# Altium schematic sheet USBandPOWER.SchDoc
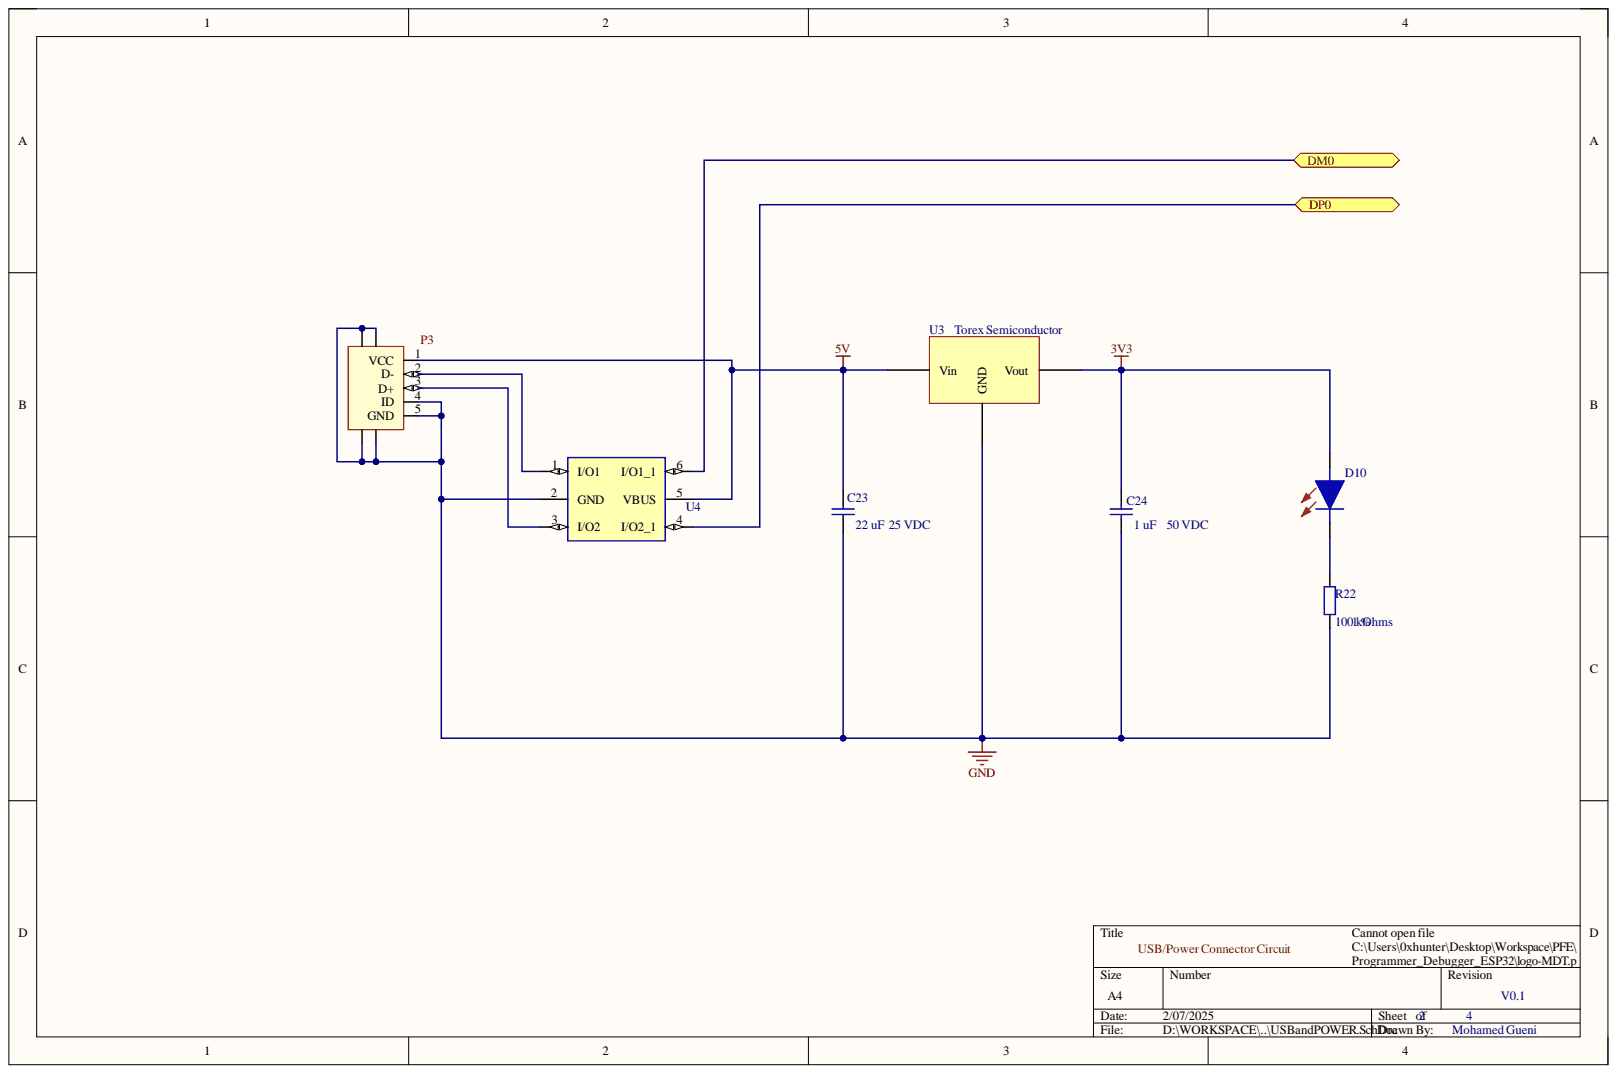
[diagram p0: USBandPOWER.SchDoc]

## Parts
C23  Value 1: 22 uF  [CAP-1210]  (hidden comment: Capacitor)
C24  Value 1: 1 uF  [CAP-1210]  (hidden comment: Capacitor)
D10  SML-H12U8TT86C  [0805 (2012 metric)]  (value not drawn)
P3  USB_minB  [51387-0530]  (value not drawn)
R22  TOL: 1 %  Value 1: 100 kOhms  [R0603]  (hidden comment: Resistor)
U3  MANREF: Torex Semiconductor  [REG-SOT230P700X180-4N]  (hidden comment: Voltage Regulator)
U4  USBLC6-2SC6  [SOT95P280X145]  (value not drawn)

## Ports
DM0, DP0

## Nets
3V3: C24.2 D10.2 U3.3
5V: C23.2 P3.1(VCC) U3.2 U4.5(VBUS)
GND: C23.1 C24.1 P3.4(ID) P3.5(GND) P3.SH1 P3.SH2 P3.SH3 P3.SH4 R22.1 U3.1 U4.2(GND)
DM0: U4.6(I/O1_1) ; port DM0
DP0: U4.4(I/O2_1) ; port DP0
NetD10_1: D10.1 R22.2
NetP3_2: P3.2(D-) U4.1(I/O1)
NetP3_3: P3.3(D+) U4.3(I/O2)

## Library data of the parts
C23 = C-000000004: Database Table Name: Capacitors; DES: Multilayer Ceramic Capacitors MLCC - SMD/SMT 22 uF 25 VDC 10% 1210 X7R; FTP: 1210; MAN: Murata Electronics; MANREF: GRM32ER71E226KE15L; NOTE: _; SUP: Mouser; SUPREF: 81-GRM32ER71E226KE5L; TOL: 10 %; Value 2: 25 VDC; Component Kind: Standard; Description: Capacitor; Library Name: DATABASE.DbLib; Part Number: C-000000004
C24 = C-000000005: Database Table Name: Capacitors; DES: Multilayer Ceramic Capacitors MLCC - SMD/SMT 50V 1uF X7R 1210 10%; FTP: 1210; MAN: TAIYO YUDEN; MANREF: UMK325B7105KH-T; NOTE: _; SUP: Mouser; SUPREF: 963-UMK325B7105KH-T; TOL: 10 %; Value 2: 50 VDC; Component Kind: Standard; Description: Capacitor; Library Name: DATABASE.DbLib; Part Number: C-000000005
D10 = LED-0002: ROHM - SML-H12U8TT86C - CHIP LED, AEC-Q101, RED, 40MCD, 0805; DES: Standard LEDs - SMD Red 620nm 40mcd 2.2V; 20mA; 0603; Geometry.Height: 0.9mm; MAN: ROHM Semiconductor; SUP: Mouser; SUPREF: 755-SML-H12U8TT86C; Value 1: 20 mA; Value 2: 2.2 V; Component Kind: Standard; Database Table Name: LED; DataSheet: https://eu.mouser.com/datasheet/2/348/sml-h1(c)-e-1508182.pdf; Datasheet Link: https://componentsearchengine.com/Datasheets/1/SML-H12U8TT86C.pdf; Library Name: DATABASE.DbLib; MANREF: SML-H12U8TT86C; Manufacturer_Name: ROHM Semiconductor; Manufacturer_Part_Number: SML-H12U8TT86C; Mouser Part Number: 755-SML-H12U8TT86C; Mouser Price/Stock: https://www.mouser.com/Search/Refine.aspx?Keyword=755-SML-H12U8TT86C; N°: LED-0002
P3 = J-000000002: Database Table Name: Connectors; FTP: THT; MAN: DataBase\PCBLibrary.PcbLib; MANREF: Molex; NOTE: _; SUP: Mouser; SUPREF: 538-51387-0530; Component Kind: Standard; DES: 51387-0530; Library Name: DATABASE.DbLib; Part Number: J-000000002
R22 = R-000000002: Database Table Name: Resistors; DES: Thick Film Resistors - SMD 1/10watt 100Kohms 1%; FTP: 0603; MAN: Vishay; MANREF: CRCW0603100KFKEA; SUP: Mouser; SUPREF: 71-CRCW0603-100K-E3; Value 2: 100 mW; Component Kind: Standard; Description: Resistor; Library Name: DATABASE.DbLib; NOTE: -; Part Number: R-000000002
U3 = U-000000004: Database Table Name: Integrated Circuits; DES: XC6203E332FR-G; FTP: 8 V; MAN: LDO Voltage Regulators; SUP: 3.3 V; TOL: 865-XC6203E332FR-G; Value 1: Mouser; Value 2: SOT-223-3; Component Kind: Standard; Library Name: DATABASE.DbLib; NOTE: https://eu.mouser.com/datasheet/2/760/XC6203-846590.pdf; Part Number: U-000000004
U4 = U-000000006: Database Table Name: Integrated Circuits; Geometry.Height: 1.45mm; MAN: ESD Suppressors / TVS Diodes ESD Protection Low Cap; MANREF: STMicroelectronics; TOL: 511-USBLC6-2SC6; Value 1: Mouser; Value 2: SOT-666; Component Kind: Standard; Datasheet Link: https://componentsearchengine.com/Datasheets/1/USBLC6-2SC6.pdf; DES: USBLC6-2SC6; Library Name: DATABASE.DbLib; Manufacturer_Name: STMicroelectronics; Manufacturer_Part_Number: USBLC6-2SC6; Mouser Part Number: 511-USBLC6-2SC6; Mouser Price/Stock: https://www.mouser.com/Search/Refine.aspx?Keyword=511-USBLC6-2SC6; NOTE: https://eu.mouser.com/datasheet/2/389/usblc6-2-957370.pdf; Part Number: U-000000006
